# Altium schematic sheet HDMI.SchDoc
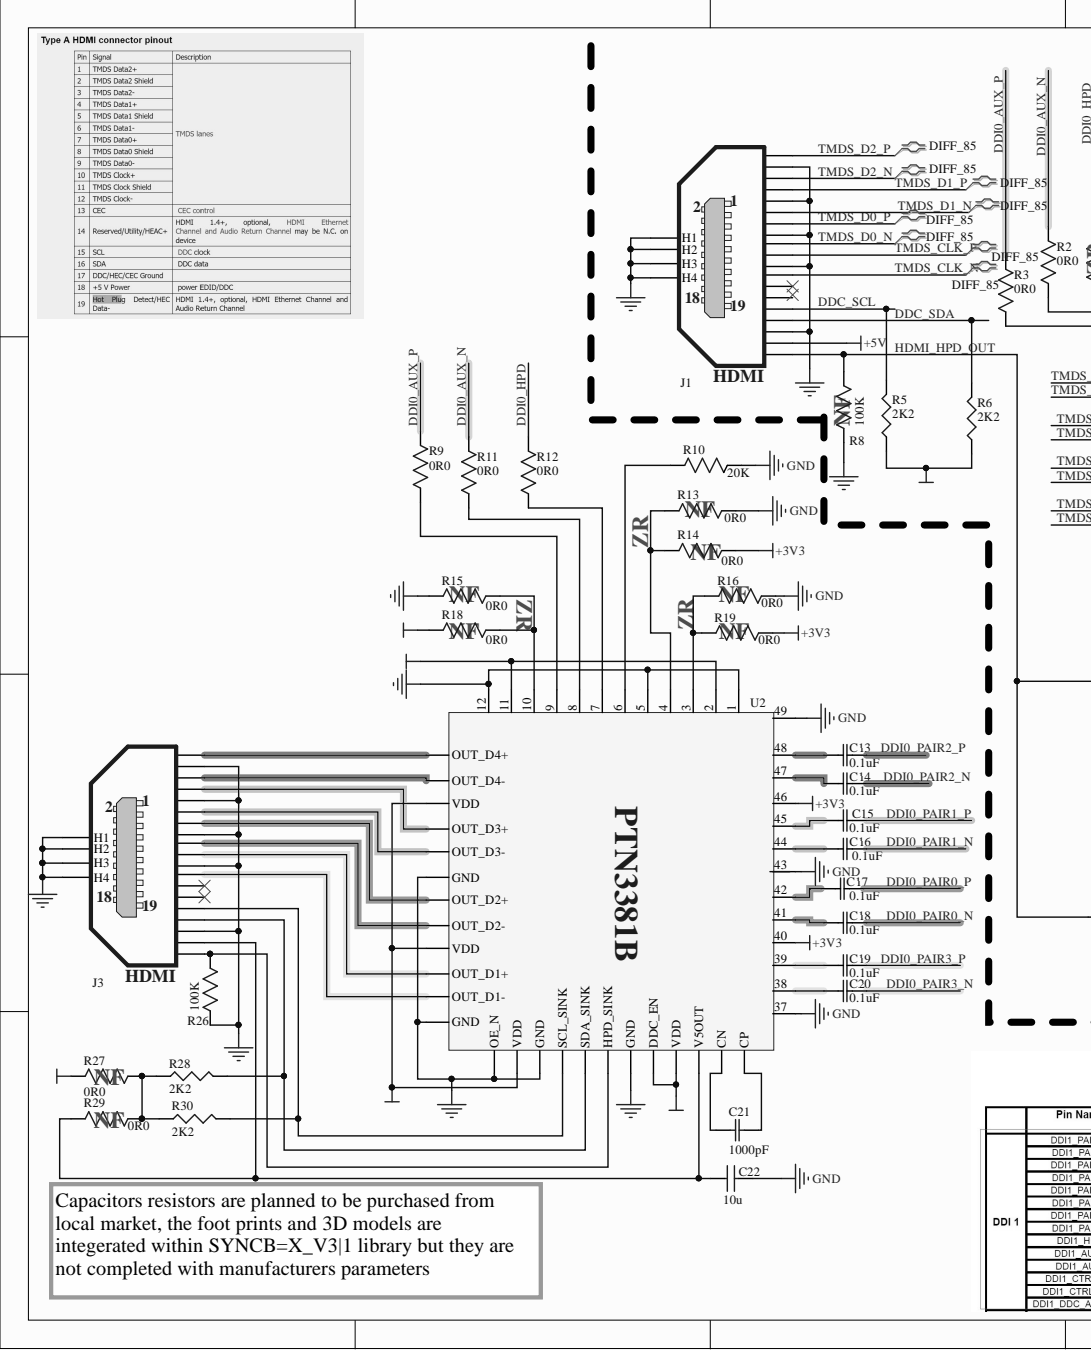
[diagram p0: HDMI.SchDoc, left]
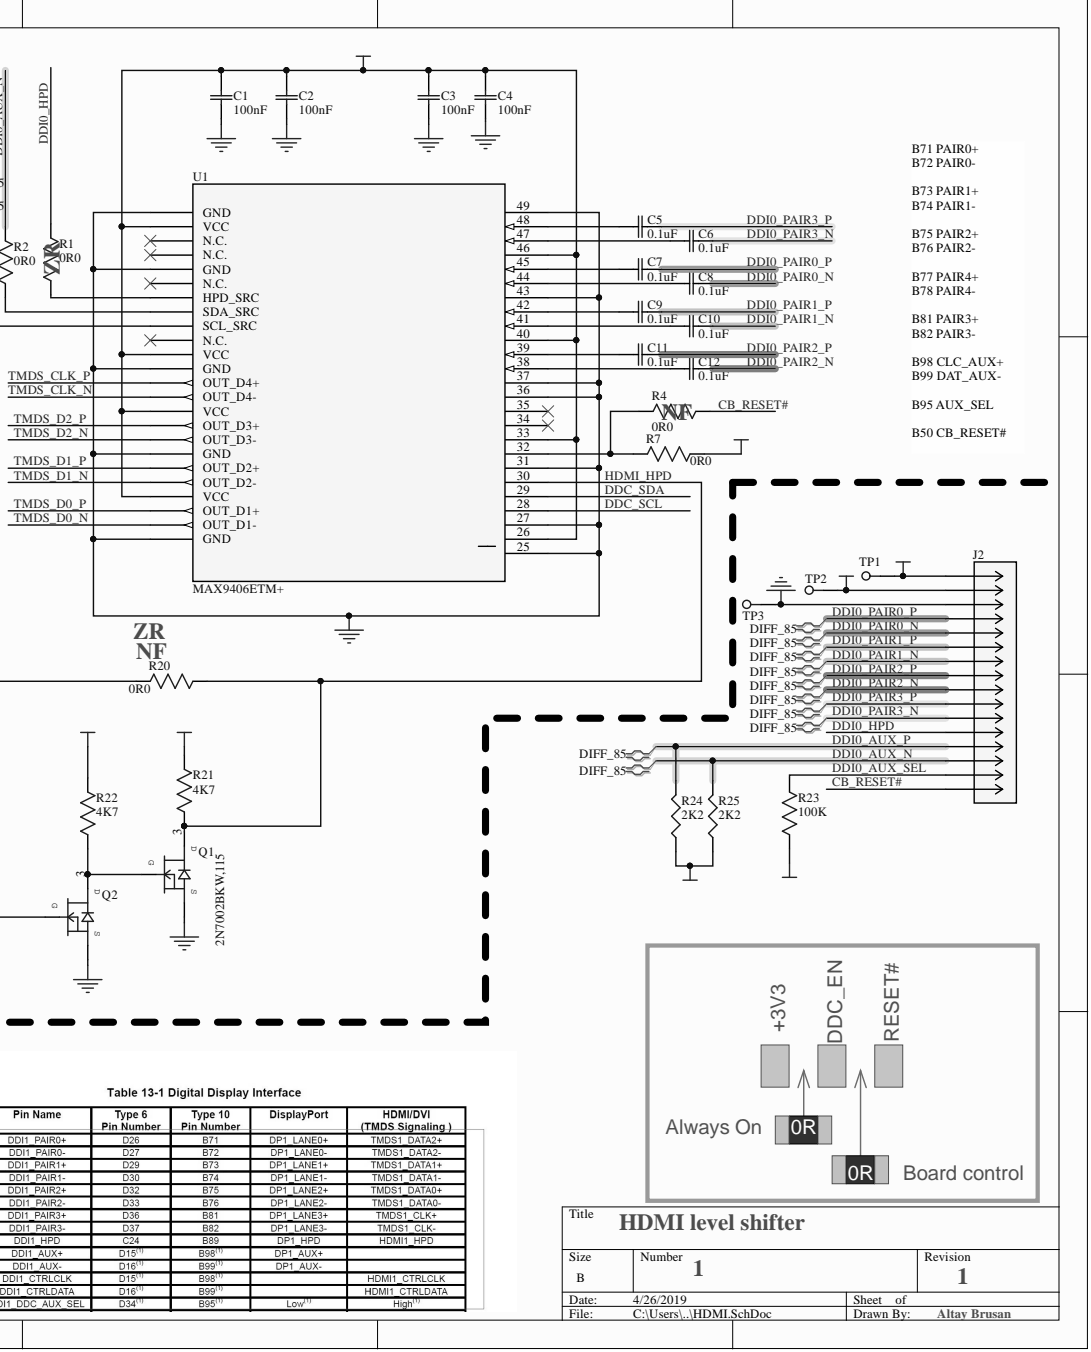
[diagram p1: HDMI.SchDoc, right]

## Parts
C1  100nF  [STA_RMCF1206-M]
C2  100nF  [STA_RMCF1206-M]
C3  100nF  [STA_RMCF1206-M]
C4  100nF  [STA_RMCF1206-M]
C5  0.1uF  [CAP_3216]
C6  0.1uF  [CAP_3216]
C7  0.1uF  [CAP_3216]
C8  0.1uF  [CAP_3216]
C9  0.1uF  [CAP_3216]
C10  0.1uF  [CAP_3216]
C11  0.1uF  [CAP_3216]
C12  0.1uF  [CAP_3216]
C13  0.1uF  [CAP_3216]
C14  0.1uF  [CAP_3216]
C15  0.1uF  [CAP_3216]
C16  0.1uF  [CAP_3216]
C17  0.1uF  [CAP_3216]
C18  0.1uF  [CAP_3216]
C19  0.1uF  [CAP_3216]
C20  0.1uF  [CAP_3216]
C21  1000pF  [C1206]
C22  10u  [C0603]
J1  HDMI Connector  [HDMI_Thru]  (value not drawn)
J2  Part Number: FTS-108-01-F-D  [CON16_2x8_TU_FTS]  (value not drawn)
J3  HDMI Connector  [HDMI_Thru]  (value not drawn)
Q1  2N7002BKW,115  [SC70_SOT323]
Q2  2N7002BKW,115  [SC70_SOT323]
R1  0R0  [STA_RMCF1206-M]
R2  0R0  [STA_RMCF1206-M]
R3  0R0  [STA_RMCF1206-M]
R4  0R0  [STA_RMCF1206-M]
R5  2K2  [R1210]
R6  2K2  [R1210]
R7  0R0  [STA_RMCF1206-M]
R8  100K  [STA_RMCF1206-M]
R9  0R0  [STA_RMCF1206-M]
R10  20K  [STA_RMCF1206-M]
R11  0R0  [STA_RMCF1206-M]
R12  0R0  [STA_RMCF1206-M]
R13  0R0  [STA_RMCF1206-M]
R14  0R0  [STA_RMCF1206-M]
R15  0R0  [STA_RMCF1206-M]
R16  0R0  [STA_RMCF1206-M]
R18  0R0  [STA_RMCF1206-M]
R19  0R0  [STA_RMCF1206-M]
R20  0R0  [STA_RMCF1206-M]
R21  4K7  [STA_RMCF1206-M]
R22  4K7  [STA_RMCF1206-M]
R23  100K  [STA_RMCF1206-M]
R24  2K2  [R1206]
R25  2K2  [R1206]
R26  100K  [STA_RMCF1206-M]
R27  0R0  [STA_RMCF1206-M]
R28  2K2  [R1206]
R29  0R0  [STA_RMCF1206-M]
R30  2K2  [R1206]
TP1  TP_25MILC  [TP_25C]  (value not drawn)  (no BOM)
TP2  TP_25MILC  [TP_25C]  (value not drawn)  (no BOM)
TP3  TP_25MILC  [TP_25C]  (value not drawn)  (no BOM)
U1  MAX9406ETM+  [21-0144H_T4877-6]
U2  Interface - Specialized ENHNCD PERFORMANCE HDMI/DVI SHFTR V-REG  [HVQFN48]  (value not drawn)

## Nets
+3V3: C1.2 C2.2 C3.2 C4.2 J2.1 R7.2 R14.1 R18.2 R19.1 R21.1 R22.1 R23.2 R24.1 R25.1 TP1.1 U1.2(VCC) U1.11(VCC) U1.15(VCC) U1.21(VCC) U1.26 U1.33 U1.40 U1.46 U2.2 U2.11 U2.15(VDD) U2.21(VDD) U2.26(VDD) U2.32(DDC_EN) U2.33(VDD) U2.40 U2.46
+5V: J1.18 J2.2 R5.1 R6.1 R27.2 TP2.1
GND: C1.1 C2.1 C3.1 C4.1 C22.2 J1.2 J1.5 J1.8 J1.11 J1.17 J1.H1 J1.H2 J1.H3 J1.H4 J2.3 J3.2 J3.5 J3.8 J3.11 J3.17 J3.H1 J3.H2 J3.H3 J3.H4 Q1.2 Q2.2 R8.2 R10.2 R13.1 R15.2 R16.1 R26.2 TP3.1 U1.1(GND) U1.5(GND) U1.12(GND) U1.18(GND) U1.24(GND) U1.25 U1.27 U1.31 U1.36 U1.37 U1.43 U1.49 U2.1 U2.5 U2.12 U2.18(GND) U2.24(GND) U2.25(OE_N) U2.27(GND) U2.31(GND) U2.37 U2.43 U2.49
CB_RESET#: J2.16 R4.2
DDC_SCL: J1.15 R5.2 U1.28
DDC_SDA: J1.16 R6.2 U1.29
DDI0_AUX_N: J2.14 R2.2 R11.2 R25.2
DDI0_AUX_P: J2.13 R3.2 R9.2 R24.2
DDI0_AUX_SEL: J2.15 R23.1
DDI0_HPD: J2.12 R1.2 R12.2
DDI0_PAIR0_N: C8.2 C18.2 J2.5
DDI0_PAIR0_P: C7.2 C17.2 J2.4
DDI0_PAIR1_N: C10.2 C16.2 J2.7
DDI0_PAIR1_P: C9.2 C15.2 J2.6
DDI0_PAIR2_N: C12.2 C14.2 J2.9
DDI0_PAIR2_P: C11.2 C13.2 J2.8
DDI0_PAIR3_N: C6.2 C20.2 J2.11
DDI0_PAIR3_P: C5.2 C19.2 J2.10
HDMI_HPD: Q1.3 R20.2 R21.2 U1.30
HDMI_HPD_OUT: J1.19 Q2.1 R8.1 R20.1
TMDS_CLK_N: J1.12 U1.14(OUT_D4-)
TMDS_CLK_P: J1.10 U1.13(OUT_D4+)
TMDS_D0_N: J1.9 U1.23(OUT_D1-)
TMDS_D0_P: J1.7 U1.22(OUT_D1+)
TMDS_D1_N: J1.6 U1.20(OUT_D2-)
TMDS_D1_P: J1.4 U1.19(OUT_D2+)
TMDS_D2_N: J1.3 U1.17(OUT_D3-)
TMDS_D2_P: J1.1 U1.16(OUT_D3+)
NetC5_1: C5.1 U1.48
NetC6_1: C6.1 U1.47
NetC7_1: C7.1 U1.45
NetC8_1: C8.1 U1.44
NetC9_1: C9.1 U1.42
NetC10_1: C10.1 U1.41
NetC11_1: C11.1 U1.39
NetC12_1: C12.1 U1.38
NetC13_1: C13.1 U2.48
NetC14_1: C14.1 U2.47
NetC15_1: C15.1 U2.45
NetC16_1: C16.1 U2.44
NetC17_1: C17.1 U2.42
NetC18_1: C18.1 U2.41
NetC19_1: C19.1 U2.39
NetC20_1: C20.1 U2.38
NetC21_1: C21.1 U2.36(CP)
NetC21_2: C21.2 U2.35(CN)
NetC22_1: C22.1 J3.18 R29.1 U2.34(V5OUT)
NetJ3_1: J3.1 U2.13(OUT_D4+)
NetJ3_3: J3.3 U2.14(OUT_D4-)
NetJ3_4: J3.4 U2.16(OUT_D3+)
NetJ3_6: J3.6 U2.17(OUT_D3-)
NetJ3_7: J3.7 U2.19(OUT_D2+)
NetJ3_9: J3.9 U2.20(OUT_D2-)
NetJ3_10: J3.10 U2.22(OUT_D1+)
NetJ3_12: J3.12 U2.23(OUT_D1-)
NetJ3_15: J3.15 R30.2 U2.28(SCL_SINK)
NetJ3_16: J3.16 R28.2 U2.29(SDA_SINK)
NetJ3_19: J3.19 R26.1 U2.30(HPD_SINK)
NetQ1_1: Q1.1 Q2.3 R22.2
NetR1_1: R1.1 U1.7(HPD_SRC)
NetR2_1: R2.1 U1.8(SDA_SRC)
NetR3_1: R3.1 U1.9(SCL_SRC)
NetR4_1: R4.1 R7.1 U1.32
NetR9_1: R9.1 U2.9
NetR10_1: R10.1 U2.6
NetR11_1: R11.1 U2.8
NetR12_1: R12.1 U2.7
NetR13_2: R13.2 R14.2 U2.4
NetR15_1: R15.1 R18.1 U2.10
NetR16_2: R16.2 R19.2 U2.3
NetR27_1: R27.1 R28.1 R29.2 R30.1
N00021:

Not connected: J1 13 14; J3 13 14; U1 3(N.C.) 4(N.C.) 6(N.C.) 10(N.C.) 34 35

## Library data of the parts
C1-C4 = CL05A104KA5NNNC: CAP CER 0.1UF 50V X8R 0603; Manufacturer 1: Samsung Electro-Mechanics; Description 1: CAP CER 0.1UF 25V X5R 0402; Notification: Current market demand for these product types have resulted in fluctuating and extending lead times. Lead times may differ.; Temperature Coefficient: X5R; Tolerance: ±10%; Voltage - Rated: 25V; Applications: General Purpose; Category: Capacitors; Component Kind: Standard; ComponentLink1Description: http://www.samsungsem.com/kr/support/product-search/mlcc/CL05A104KA5NNNC.jsp; ComponentLink1URL: http://www.samsungsem.com/kr/support/product-search/mlcc/CL05A104KA5NNNC.jsp; Features: -; Height - Seated (Max): -; Lead Spacing: -; Lead Style: -; Manufacturer Part Number 1: CL05A104KA5NNNC; Mounting Type: Surface Mount, MLCC; Operating Temperature: -55°C ~ 85°C; Package / Case: 0402 (1005 Metric); Packaging: Digi-Reel®; Part Status: Active; Pricing 1: 1=0.1, 10=0.04, 100=0.0176, 500=0.0126, 1000=0.0099, 2500=0.009, 5000=0.00828 (USD); Ratings: -; RoHS: RoHS Compliant; Size / Dimension: 0.039" L x 0.020" W (1.00mm x 0.50mm); Stock 1: 100000; Supplier 1: Digi-Key; Supplier Part Number 1: 1276-1043-6-ND; Thickness (Max): 0.022" (0.55mm); Library Name: SYNCBOX_V3I1.SCHLIB
C5-C20 = C3216X8R2A104K11: CAP CER 0.1UF 100V X8R 1206; Manufacturer_Part_Number: C3216X8R2A104K115AA; Built_By: EMA_UL_Team; Type: DEV; Vendor: TDK; Component Kind: Standard; RefDes: RefDes; Value: Value; Copyright: Copyright (C) 2018 Accelerated Designs. All rights reserved; Library Name: SYNCBOX_V3I1.SCHLIB
C21 = C1206X102KGRACAUTO: CAP CER 1206 1NF 2000V X7R 10% F; Manufacturer 1: KEMET; Voltage - Rated: 2000V (2kV); Applications: Automotive, Boardflex Sensitive; Category: Capacitors; Component Kind: Standard; ComponentLink1Description: https://api.kemet.com/component-edge/download/datasheet/C1206X102KGRACAUTO.pdf; ComponentLink1URL: https://api.kemet.com/component-edge/download/datasheet/C1206X102KGRACAUTO.pdf; Description 1: CAP CER 1206 1NF 2000V X7R 10% F; Failure Rate: -; Features: Low ESL, Soft Termination, High Voltage; Height - Seated (Max): -; Lead Spacing: -; Lead Style: -; Manufacturer Part Number 1: C1206X102KGRACAUTO; Mounting Type: Surface Mount, MLCC; Operating Temperature: -55°C ~ 125°C; Package / Case: 1206 (3216 Metric); Packaging: Cut Tape (CT); Part Status: Active; Pricing 1: 1=0.79, 10=0.58, 100=0.3974, 500=0.31464, 1000=0.26496 (USD); Ratings: AEC-Q200; RoHS: RoHS Compliant; Size / Dimension: 0.130" L x 0.063" W (3.30mm x 1.60mm); Stock 1: 7503; Supplier 1: Digi-Key; Supplier Part Number 1: 399-16730-1-ND; Temperature Coefficient: X7R; Thickness (Max): 0.073" (1.85mm); Tolerance: ±10%; Library Name: SYNCBOX_V3I1.SCHLIB
C22 = C1608X5R0J106M: CAP CER 10UF 6.3V X5R 20% 0603; Manufacturer: TDK Corporation; .Checked PCB: used; Capacitance: 10µF; Value: 10µF; .Checked SCH: used; Applications: General Purpose; Category: Capacitors; Component Kind: Standard; ComponentLink1Description: http://www.tdk.com/pdf/general_B11.pdf; ComponentLink1URL: http://www.tdk.com/pdf/general_B11.pdf; Features: Low ESR; Height - Seated (Max): -; Lead Spacing: -; Lead Style: -; Manufacturer Part Number: C1608X5R0J106M; Mounting Type: Surface Mount, MLCC; Operating Temperature: -55°C ~ 85°C; Package / Case: 0603 (1608 Metric); Packaging: Cut Tape (CT); Price 1k [$]: 0.08580; Pricing 1: 1=0.46, 10=0.363, 100=0.1898, 250=0.14024, 500=0.1089, 1000=0.0858 (USD); Ratings: -; RoHS: RoHS Compliant; Size / Dimension: 0.063" L x 0.031" W (1.60mm x 0.80mm); Stock 1: 48157; Supplier: Digikey; Supplier 1: Digi-Key; Supplier Part Number: 445-4112-1-ND; Supplier Part Number 1: 445-4112-1-ND; Temperature Coefficient: X5R; Thickness (Max): 0.037" (0.95mm); Tolerance: ±20%; Voltage - Rated: 6.3V; Library Name: SYNCBOX_V3I1.SCHLIB
J1 J3 = HDMI_Connector: Component Kind: Standard; Library Name: SYNCBOX_V3I1.SCHLIB
J2 = FTS-108-01-F-D: No Description Available; Built_By: EMA_UL_Team; Vendor: Samtec Inc; Component Kind: Standard; Manufacturer_Part_Number: FTS-108-01-F-D; RefDes: RefDes; Type: DEV; Value: Value; Copyright: Copyright (C) 2018 Accelerated Designs. All rights reserved; Library Name: SYNCBOX_V3I1.SCHLIB
Q1 Q2 = 2N7002BKW,115: MOSFET N-CH 60V 310MA SOT323; Manufacturer: NXP Semiconductors; .Checked PCB: RF; FET Feature: Logic Level Gate; FET Type: MOSFET N-Channel, Metal Oxide; Gate Charge (Qg) @ Vgs: 0.6nC @ 4.5V; Input Capacitance (Ciss) @ Vds: 50pF @ 10V; Power - Max: 275mW; Rds On (Max) @ Id, Vgs: 1.6 Ohm @ 500mA, 10V; Vgs(th) (Max) @ Id: 2.1V @ 250µA; Category: Discrete Semiconductor Products; Component Kind: Standard; ComponentLink1Description: http://www.nxp.com/documents/data_sheet/2N7002BKW.pdf; ComponentLink1URL: http://www.nxp.com/documents/data_sheet/2N7002BKW.pdf; Current - Continuous Drain (Id) @ 25° C: 310mA; Drain to Source Voltage (Vdss): 60V; Manufacturer Part Number: 2N7002BKW,115; Mounting Type: Surface Mount; Package / Case: SC-70, SOT-323; Packaging: Cut Tape (CT); Pricing 1: 1=0.39, 10=0.354, 25=0.2548, 100=0.1983, 250=0.12456, 500=0.10616, 1000=0.07233 (USD); RoHS: RoHS Compliant; Stock 1: 15666; Supplier 1: Digi-Key; Supplier Device Package: SC-70; Supplier Part Number 1: 568-5980-1-ND; .Checked SCH: RF; Library Name: SYNCBOX_V3I1.SCHLIB
R1-R4 R7 R9 R11-R16 R18-R20 R27 R29 = RMCF1206JT390R: No Description Available; Manufacturer 1: Stackpole-Electronics-Inc.; Built_By: EMA_UL_Team; Power (Watts): 0.25W, 1/4W; Resistance (Ohms): 390; Temperature Coefficient: ±200ppm/°C; Tolerance: ±5%; Vendor: Stackpole International; Category: Resistors; Component Kind: Standard; Composition: Thick Film; Family: Chip Resistor - Surface Mount; Features: Automotive AEC-Q200; Height: 0.028" (0.70mm); Manufacturer_Part_Number: RMCF1206JT390R; Manufacturer Part Number 1: RMCF1206JT390R; Number of Terminations: 2; Operating Temperature: -55°C ~ 155°C; Package / Case: 1206 (3216 Metric); Packaging: Digi-Reel®; Pricing 1: 5000=0.004, 25000=0.0038, 50000=0.0035, 125000=0.0031, 250000=0.0028 (USD); RefDes: RefDes; Series: RMCF; Size / Dimension: 0.126" L x 0.063" W (3.20mm x 1.60mm); Stock 1: 15000; Supplier 1: Future Electronics; Supplier Device Package: 1206; Supplier Part Number 1: RMCF1206JT390R-REEL; Type: DEV; Value: Value; Copyright: Copyright (C) 2018 Accelerated Designs. All rights reserved; Library Name: SYNCBOX_V3I1.SCHLIB
R5 R6 = RC1005F222CS: RES.(3225) 1210 2.2K Ohms 1% 1/3W-S 100PPM; Component Kind: Standard; Package / Case: 1210; Tolerance: ±1%; Library Name: SYNCBOX_V3I1.SCHLIB
R8 R23 R26 = RC2012F104CS: RES.(3216) 1206 100K Ohms 1% 1/4W-S 100PPM; Component Kind: Standard; Tolerance: ±1%; Library Name: SYNCBOX_V3I1.SCHLIB
  R8 R23: Package / Case: 1206
  R26: Supplier Device Package: 1206
R10 = RC2012F203CS: RES.(3216) 1206 20K Ohms 1% 1/4W-S 100PPM; Component Kind: Standard; Supplier Device Package: 1206; Tolerance: ±1%; Library Name: SYNCBOX_V3I1.SCHLIB
R21 R22 = CRG0805F4K7: RES SMD 4.7K OHM 1% 1/8W 0805; Manufacturer 1: TE Connectivity Passive Product; Built_By: EMA_UL_Team; C: Copyright (C) 2015 Accelerated Designs. All rights reserved; Power (Watts): 0.125W, 1/8W; Temperature Coefficient: ±100ppm/°C; Vendor: TE Connectivity; Category: Resistors; Component Kind: Standard; ComponentLink1Description: http://www.te.com/commerce/DocumentDelivery/DDEController?Action=srchrtrv&DocNm=1773204&DocType=DS&DocLang=English; ComponentLink1URL: http://www.te.com/commerce/DocumentDelivery/DDEController?Action=srchrtrv&DocNm=1773204&DocType=DS&DocLang=English; Composition: Thick Film; Description 1: RES SMD 4.7K OHM 1% 1/8W 0805; Failure Rate: -; Features: -; Height - Seated (Max): 0.026" (0.65mm); Manufacturer_Part_Number: CRG0805F4K7; Manufacturer Part Number 1: CRG0805F4K7; Operating Temperature: -55°C ~ 125°C; Package / Case: 0805 (2012 Metric); Packaging: Cut Tape (CT); Part Status: Active; Pricing 1: 1=0.1, 10=0.035, 100=0.0143, 1000=0.00641, 2500=0.00557 (USD); RefDes: RefDes; RoHS: RoHS Compliant; Size / Dimension: 0.079" L x 0.049" W (2.00mm x 1.25mm); Stock 1: 28633; Supplier 1: Digi-Key; Supplier Device Package: 0805; Supplier Part Number 1: A106065CT-ND; Tolerance: ±1%; Type: DEV; Value: Value; Library Name: SYNCBOX_V3I1.SCHLIB
R24 R25 R28 R30 = RC1005F222CS: RES.(3216) 1206 2.2K Ohms 1% 1/4W-S 100PPM; Component Kind: Standard; Tolerance: ±1%; Library Name: SYNCBOX_V3I1.SCHLIB
  R24 R25: Package / Case: 1206
  R28 R30: Supplier Device Package: 1206
TP1-TP3 = TP_25MILC: Test Point 25mil; Library Name: SYNCBOX_V3I1.SCHLIB
U1 = MAX9406ETM+: IC DVI/HDMI LVL SHIFTR 48TQFN; Manufacturer 1: Maxim Integrated; Applications: Base Stations; Base Part Number: MAX9406; Category: Integrated Circuits (ICs); Component Kind: Standard; ComponentLink1Description: https://datasheets.maximintegrated.com/en/ds/MAX9406.pdf; ComponentLink1URL: https://datasheets.maximintegrated.com/en/ds/MAX9406.pdf; Description 1: IC DVI/HDMI LVL SHIFTR 48TQFN; Manufacturer Part Number 1: MAX9406ETM+; Mounting Type: Surface Mount; Package / Case: 48-WFQFN Exposed Pad; Packaging: Tube; Part Status: Active; Pricing 1: 1=3.75, 10=3.563, 25=2.94, 50=2.793, 250=2.70752, 500=2.44832 (USD); RoHS: RoHS Compliant; Stock 1: 162; Supplier 1: Digi-Key; Supplier Device Package: 48-TQFN (7x7); Supplier Part Number 1: MAX9406ETM+-ND; Type: Level Shifter; Library Name: SYNCBOX_V3I1.SCHLIB
U2 = PTN3381B: Manufacturer 1: NXP; Manufacturer Part Number 1: PTN3381BBS,518; Brand: NXP Semiconductors; Category: Interface - Specialized; Component Kind: Standard; ComponentLink1Description: https://www.mouser.com/datasheet/2/302/PTN3381B-1127737.pdf; ComponentLink1URL: https://www.mouser.com/datasheet/2/302/PTN3381B-1127737.pdf; Description 1: Interface - Specialized ENHNCD PERFORMANCE HDMI/DVI SHFTR V-REG; Minimum Order Quantity: 1; Packaging: Cut Tape; Pricing 1: 1=1.94, 10=1.65, 100=1.32, 500=1.15, 1000=0.952, 4000=0.854, 8000=0.821 (USD); Product Type: Interface - Specialized; Reeling: True; RoHS: RoHS Compliant; RoHS - Mouser: Y; Standard Pack Qty: 4000; Stock 1: 2570; Subcategory: Interface ICs; Supplier 1: Mouser; Supplier Part Number 1: 771-PTN3381BBS518; Library Name: SYNCBOX_V3I1.SCHLIB
